# Altium schematic sheet logic.SchDoc
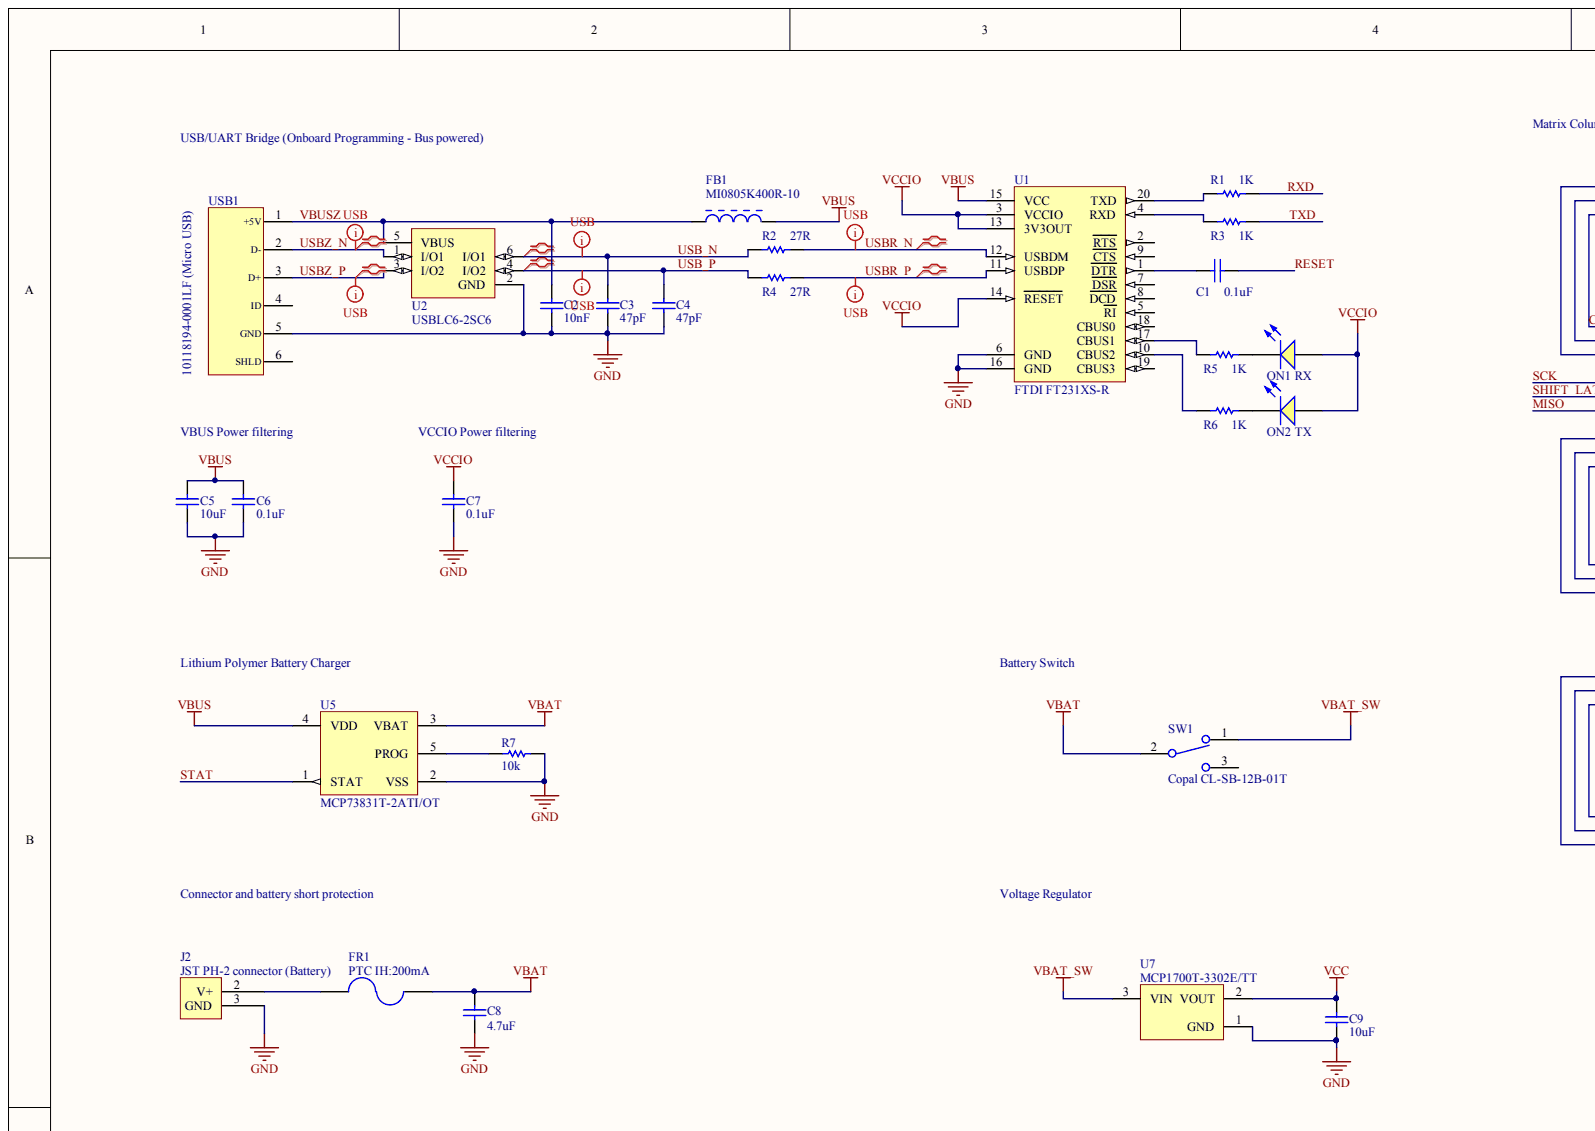
[diagram p0: logic.SchDoc, top left]
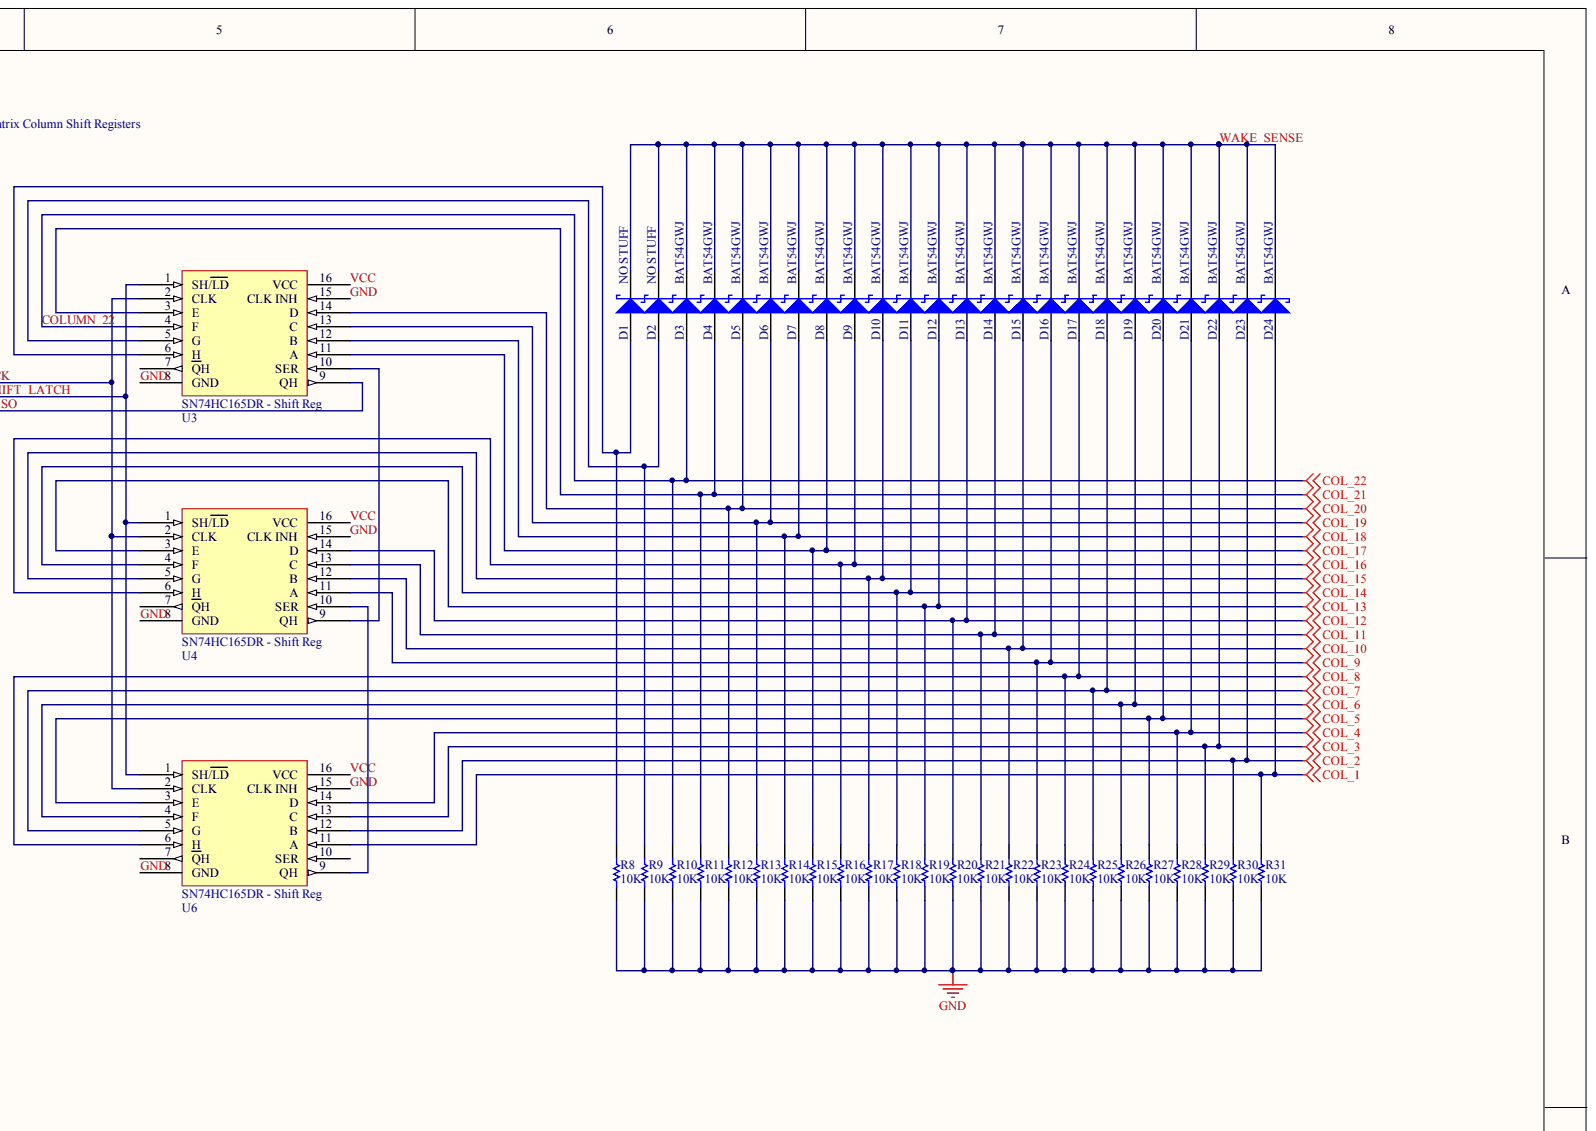
[diagram p1: logic.SchDoc, top right]
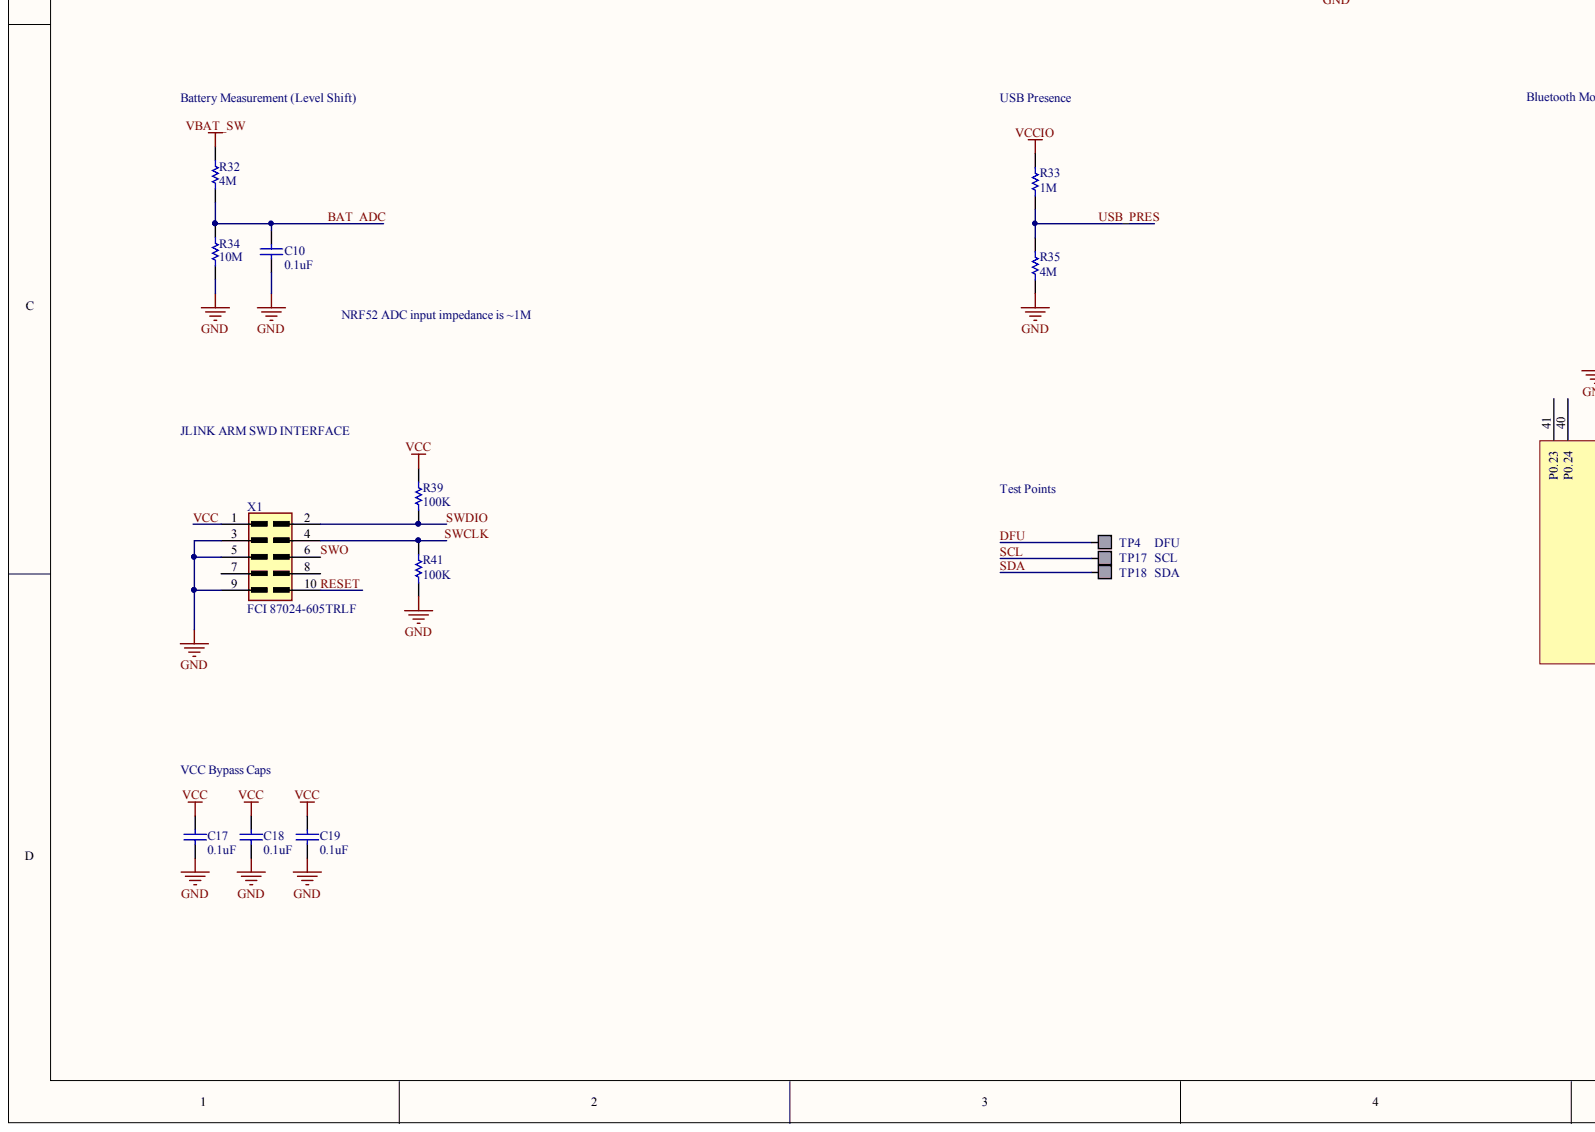
[diagram p2: logic.SchDoc, bottom left]
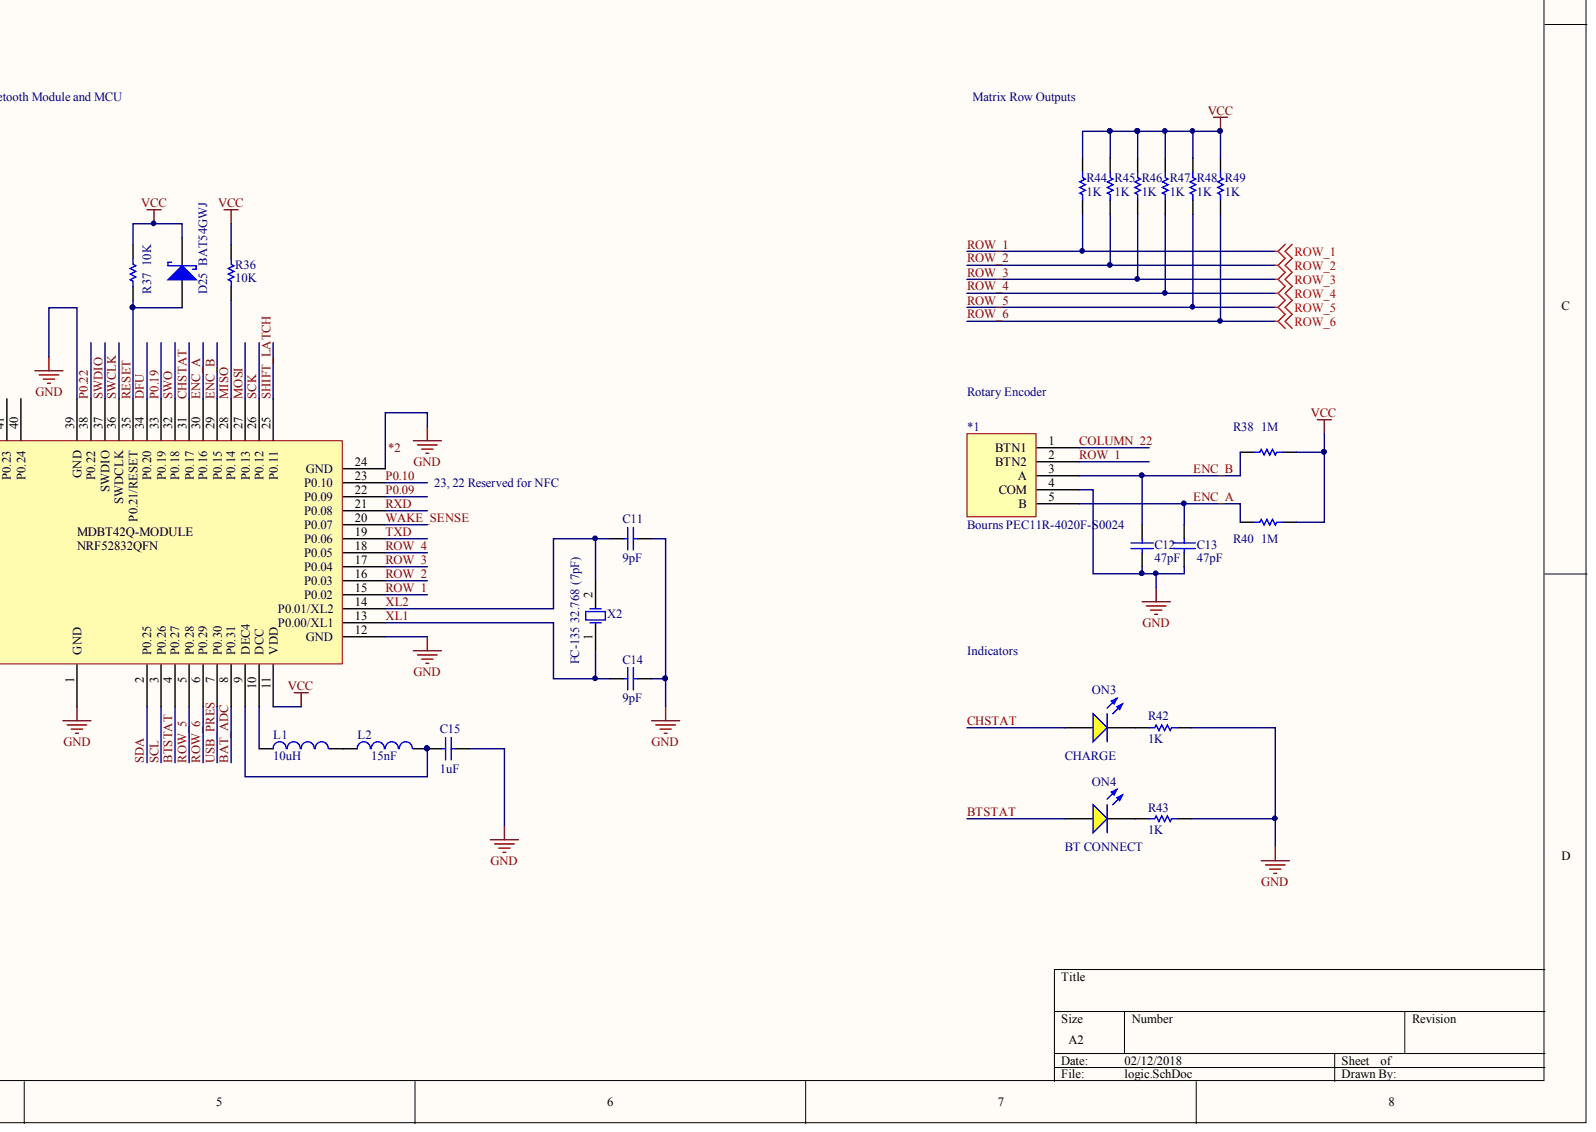
[diagram p3: logic.SchDoc, bottom right]

## Parts
*1  Bourns PEC11R-4020F-S0024  Manufacturer Part Number: PEC11R-4020F-S0024  [PCB-7675167-1]
*2  Raytac MDBT42 BT Module  [PCB-dat7rp2to0iz7tu5ms73-1]  (value not drawn)
C1  0.1uF  [CAPC2013X135X45ML10T25]
C2  10nF  [CAPC2013X135X45ML10T25]
C3  47pF  [CAPC2013X135X45ML10T25]
C4  47pF  [CAPC2013X135X45ML10T25]
C5  10uF  [CAPC2013X135X45ML10T25]
C6  0.1uF  [CAPC2013X135X45ML10T25]
C7  0.1uF  [CAPC2013X135X45ML10T25]
C8  4.7uF  [CAPC2013X135X45ML10T25]
C9  10uF  [CAPC2013X135X45ML10T25]
C10  0.1uF  [CAPC2013X135X45ML10T25]
C11  9pF  [CAPC2013X135X45ML10T25]
C12  47pF  [CAPC2013X135X45ML10T25]
C13  47pF  [CAPC2013X135X45ML10T25]
C14  9pF  [CAPC2013X135X45ML10T25]
C15  1uF  [CAPC2013X135X45ML10T25]
C17  0.1uF  [CAPC2013X135X45ML10T25]
C18  0.1uF  [CAPC2013X135X45ML10T25]
C19  0.1uF  [CAPC2013X135X45ML10T25]
D1  NO STUFF  [PCB-9vocbhu4iqy0s6v5ngz6-1]
D2  NO STUFF  Manufacturer Part Number: BAT54GWJ  [PCB-9vocbhu4iqy0s6v5ngz6-1]
D3  BAT54GWJ  [PCB-9vocbhu4iqy0s6v5ngz6-1]
D4  BAT54GWJ  [PCB-9vocbhu4iqy0s6v5ngz6-1]
D5  BAT54GWJ  [PCB-9vocbhu4iqy0s6v5ngz6-1]
D6  BAT54GWJ  [PCB-9vocbhu4iqy0s6v5ngz6-1]
D7  BAT54GWJ  [PCB-9vocbhu4iqy0s6v5ngz6-1]
D8  BAT54GWJ  [PCB-9vocbhu4iqy0s6v5ngz6-1]
D9  BAT54GWJ  [PCB-9vocbhu4iqy0s6v5ngz6-1]
D10  BAT54GWJ  [PCB-9vocbhu4iqy0s6v5ngz6-1]
D11  BAT54GWJ  [PCB-9vocbhu4iqy0s6v5ngz6-1]
D12  BAT54GWJ  [PCB-9vocbhu4iqy0s6v5ngz6-1]
D13  BAT54GWJ  [PCB-9vocbhu4iqy0s6v5ngz6-1]
D14  BAT54GWJ  [PCB-9vocbhu4iqy0s6v5ngz6-1]
D15  BAT54GWJ  [PCB-9vocbhu4iqy0s6v5ngz6-1]
D16  BAT54GWJ  [PCB-9vocbhu4iqy0s6v5ngz6-1]
D17  BAT54GWJ  [PCB-9vocbhu4iqy0s6v5ngz6-1]
D18  BAT54GWJ  [PCB-9vocbhu4iqy0s6v5ngz6-1]
D19  BAT54GWJ  [PCB-9vocbhu4iqy0s6v5ngz6-1]
D20  BAT54GWJ  [PCB-9vocbhu4iqy0s6v5ngz6-1]
D21  BAT54GWJ  [PCB-9vocbhu4iqy0s6v5ngz6-1]
D22  BAT54GWJ  [PCB-9vocbhu4iqy0s6v5ngz6-1]
D23  BAT54GWJ  [PCB-9vocbhu4iqy0s6v5ngz6-1]
D24  BAT54GWJ  [PCB-9vocbhu4iqy0s6v5ngz6-1]
D25  BAT54GWJ  [PCB-9vocbhu4iqy0s6v5ngz6-1]
FB1  MI0805K400R-10  [MURA-BLM21-H1-CHIP-2_V]
FR1  PTC IH:200mA  [PTC-1206]
J2  JST PH-2 connector (Battery)  [PCB-i8dbac1b5x6rvqm7v5xd-1]
L1  10uH  [PCB-6xavmov8f9jbkmhuz9jz-1]
L2  15nF  [PCB-6xavmov8f9jbkmhuz9jz-1]
ON1  RX  [PCB-mx9kk1rrupqh24oxzoho-1]
ON2  TX  [PCB-mx9kk1rrupqh24oxzoho-1]
ON3  CHARGE  [PCB-mx9kk1rrupqh24oxzoho-1]
ON4  BT CONNECT  [PCB-mx9kk1rrupqh24oxzoho-1]
R1  1K  [RESC2013X60X40ML20T25]
R2  27R  [RESC2013X60X40ML20T25]
R3  1K  [RESC2013X60X40ML20T25]
R4  27R  [RESC2013X60X40ML20T25]
R5  1K  [RESC2013X60X40ML20T25]
R6  1K  [RESC2013X60X40ML20T25]
R7  10k  [RESC2013X60X40ML20T25]
R8  10K  [RESC2013X60X40ML20T25]
R9  10K  [RESC2013X60X40ML20T25]
R10  10K  [RESC2013X60X40ML20T25]
R11  10K  [RESC2013X60X40ML20T25]
R12  10K  [RESC2013X60X40ML20T25]
R13  10K  [RESC2013X60X40ML20T25]
R14  10K  [RESC2013X60X40ML20T25]
R15  10K  [RESC2013X60X40ML20T25]
R16  10K  [RESC2013X60X40ML20T25]
R17  10K  [RESC2013X60X40ML20T25]
R18  10K  [RESC2013X60X40ML20T25]
R19  10K  [RESC2013X60X40ML20T25]
R20  10K  [RESC2013X60X40ML20T25]
R21  10K  [RESC2013X60X40ML20T25]
R22  10K  [RESC2013X60X40ML20T25]
R23  10K  [RESC2013X60X40ML20T25]
R24  10K  [RESC2013X60X40ML20T25]
R25  10K  [RESC2013X60X40ML20T25]
R26  10K  [RESC2013X60X40ML20T25]
R27  10K  [RESC2013X60X40ML20T25]
R28  10K  [RESC2013X60X40ML20T25]
R29  10K  [RESC2013X60X40ML20T25]
R30  10K  [RESC2013X60X40ML20T25]
R31  10K  [RESC2013X60X40ML20T25]
R32  4M  [RESC2013X60X40ML20T25]
R33  1M  [RESC2013X60X40ML20T25]
R34  10M  [RESC2013X60X40ML20T25]
R35  4M  [RESC2013X60X40ML20T25]
R36  10K  [RESC2013X60X40ML20T25]
R37  10K  [RESC2013X60X40ML20T25]
R38  1M  [RESC2013X60X40ML20T25]
R39  100K  [RESC2013X60X40ML20T25]
R40  1M  [RESC2013X60X40ML20T25]
R41  100K  [RESC2013X60X40ML20T25]
R42  1K  [RESC2013X60X40ML20T25]
R43  1K  [RESC2013X60X40ML20T25]
R44  1K  [RESC2013X60X40ML20T25]
R45  1K  [RESC2013X60X40ML20T25]
R46  1K  [RESC2013X60X40ML20T25]
R47  1K  [RESC2013X60X40ML20T25]
R48  1K  [RESC2013X60X40ML20T25]
R49  1K  [RESC2013X60X40ML20T25]
SW1  Copal CL-SB-12B-01T  Manufacturer Part Number: CL-SB-12B-01T  [PCB-9uu377i0ea7oa49ut9v1-1]
TP4  DFU  [PCB-iek5qk9ganu4yn12lo6h-1]  (no BOM)
TP17  SCL  [PCB-iek5qk9ganu4yn12lo6h-1]  (no BOM)
TP18  SDA  [PCB-iek5qk9ganu4yn12lo6h-1]  (no BOM)
U1  FTDI FT231XS-R  Manufacturer Part Number: FT231XS-R  [SSOP20_L]
U2  USBLC6-2SC6  [MF06A_N]
U3  Texas Instruments SN74HC165D  [PCB-aaybsre3rq53r941mfjn-1]  (value not drawn)
U4  Texas Instruments SN74HC165D  [PCB-aaybsre3rq53r941mfjn-1]  (value not drawn)
U5  MCP73831T-2ATI/OT  [SOT-23-OT5_L]
U6  Texas Instruments SN74HC165D  [PCB-aaybsre3rq53r941mfjn-1]  (value not drawn)
U7  MCP1700T-3302E/TT  [SOT-23-TT3_N]
USB1  10118194-0001LF (Micro USB)  [PCB-77ljjqva4nnjq1f4jty9-1]
X1  FCI 87024-605TRLF  [PCB-sv1um6pcy0j9gku5nhxe-1]
X2  FC-135 32.768 (7pF)  [PCB-1zp5wd1v5813cd8flxka-1]

## Nets
1: J2.4
3: J2.1
COL_1: D24.1 R31.2 U6.11(A)
COL_2: D23.1 R30.2 U6.12(B)
COL_3: D22.1 R29.2 U6.13(C)
COL_4: D21.1 R28.2 U6.14(D)
COL_5: D20.1 R27.2 U6.3(E)
COL_6: D19.1 R26.2 U6.4(F)
COL_7: D18.1 R25.2 U6.5(G)
COL_8: D17.1 R24.2 U6.6(H)
COL_9: D16.1 R23.2 U4.11(A)
COL_10: D15.1 R22.2 U4.12(B)
COL_11: D14.1 R21.2 U4.13(C)
COL_12: D13.1 R20.2 U4.14(D)
COL_13: D12.1 R19.2 U4.3(E)
COL_14: D11.1 R18.2 U4.4(F)
COL_15: D10.1 R17.2 U4.5(G)
COL_16: D9.1 R16.2 U4.6(H)
COL_17: D8.1 R15.2 U3.11(A)
COL_18: D7.1 R14.2 U3.12(B)
COL_19: D6.1 R13.2 U3.13(C)
COL_20: D5.1 R12.2 U3.14(D)
COL_21: D4.1 R11.2 U3.3(E)
VBAT: C8.1 FR1.1 SW1.2 U5.3(VBAT)
VBAT_SW: R32.2 SW1.1 U7.3
VBUS: C5.1 C6.1 FB1.2 U1.15(VCC) U5.4(VDD)
VCCIO: C7.1 ON1.2 ON2.2 R33.2 U1.3(VCCIO) U1.13(3V3OUT) U1.14(RESET)
BAT_ADC: *2.8(P0.31) C10.1 R32.1 R34.2
BTSTAT: *2.4(P0.27) ON4.2
CHSTAT: *2.31(P0.17) ON3.2
COLUMN_22: *1.1(BTN1) D3.1 R10.2 U3.4(F)
DFU: *2.34(P0.20) TP4.1
ENC_A: *1.5(B) *2.30(P0.16) C13.2 R40.2
ENC_B: *1.3(A) *2.29(P0.15) C12.2 R38.2
GND: *1.4(COM) *2.1(GND) *2.12(GND) *2.24(GND) *2.39(GND) C2.2 C3.2 C4.2 C5.2 C6.2 C7.2 C8.2 C9.2 C10.2 C11.2 C12.1 C13.1 C14.2 C15.2 C17.2 C18.2 C19.2 J2.3 R7.2 R8.1 R9.1 R10.1 R11.1 R12.1 R13.1 R14.1 R15.1 R16.1 R17.1 R18.1 R19.1 R20.1 R21.1 R22.1 R23.1 R24.1 R25.1 R26.1 R27.1 R28.1 R29.1 R30.1 R31.1 R34.1 R35.1 R41.2 R42.2 R43.2 U1.6(GND) U1.16(GND) U2.2(GND) U3.8(GND) U3.15(CLK_INH) U4.8(GND) U4.15(CLK_INH) U5.2(VSS) U6.8(GND) U6.15(CLK_INH) U7.1 USB1.5(GND) X1.3 X1.5 X1.9
MISO: *2.28(P0.14) R36.1 U3.9(QH)
MOSI: *2.27(P0.13)
P0.09: *2.22(P0.09)
P0.10: *2.23(P0.10)
P0.19: *2.33(P0.19)
P0.22: *2.38(P0.22)
RESET: *2.35(P0.21/RESET) C1.1 D25.1 R37.2 X1.10
ROW_1: *1.2(BTN2) *2.15(P0.02) R44.1
ROW_2: *2.16(P0.03) R45.1
ROW_3: *2.17(P0.04) R46.1
ROW_4: *2.18(P0.05) R47.1
ROW_5: *2.5(P0.28) R48.1
ROW_6: *2.6(P0.29) R49.1
RXD: *2.21(P0.08) R1.1
SCK: *2.26(P0.12) U3.2(CLK) U4.2(CLK) U6.2(CLK)
SCL: *2.3(P0.26) TP17.1
SDA: *2.2(P0.25) TP18.1
SHIFT_LATCH: *2.25(P0.11) U3.1(SH/LD) U4.1(SH/LD) U6.1(SH/LD)
STAT: U5.1(STAT)
SWCLK: *2.36(SWDCLK) R41.1 X1.4
SWDIO: *2.37(SWDIO) R39.2 X1.2
SWO: *2.32(P0.18) X1.6
TXD: *2.19(P0.06) R3.1
USB_N: C3.1 R2.2 U2.6(I/O1)
USB_P: C4.1 R4.2 U2.4(I/O2)
USB_PRES: *2.7(P0.30) R33.1 R35.2
USBR_N: R2.1 U1.12(USBDM)
USBR_P: R4.1 U1.11(USBDP)
USBZ_N: U2.1(I/O1) USB1.2(D-)
USBZ_P: U2.3(I/O2) USB1.3(D+)
VBUSZ: C2.1 FB1.1 U2.5(VBUS) USB1.1(+5V)
VCC: *2.11(VDD) C9.1 C17.1 C18.1 C19.1 D25.2 R36.2 R37.1 R38.1 R39.1 R40.1 R44.2 R45.2 R46.2 R47.2 R48.2 R49.2 U3.16(VCC) U4.16(VCC) U6.16(VCC) U7.2 X1.1
WAKE_SENSE: *2.20(P0.07) D1.2 D2.2 D3.2 D4.2 D5.2 D6.2 D7.2 D8.2 D9.2 D10.2 D11.2 D12.2 D13.2 D14.2 D15.2 D16.2 D17.2 D18.2 D19.2 D20.2 D21.2 D22.2 D23.2 D24.2
XL1: *2.13(P0.00/XL1) C14.1 X2.1
XL2: *2.14(P0.01/XL2) C11.1 X2.2
Net*2_9: *2.9(DEC4) C15.1 L2.2
Net*2_10: *2.10(DCC) L1.1
NetC1_2: C1.2 U1.1(DTR)
NetD1_1: D1.1 R8.2 U3.6(H)
NetD2_1: D2.1 R9.2 U3.5(G)
NetFR1_2: FR1.2 J2.2
NetL1_2: L1.2 L2.1
NetON1_1: ON1.1 R5.1
NetON2_1: ON2.1 R6.1
NetON3_1: ON3.1 R42.1
NetON4_1: ON4.1 R43.1
NetR1_2: R1.2 U1.20(TXD)
NetR3_2: R3.2 U1.4(RXD)
NetR5_2: R5.2 U1.17(CBUS1)
NetR6_2: R6.2 U1.10(CBUS2)
NetR7_1: R7.1 U5.5(PROG)
NetU3_10: U3.10(SER) U4.9(QH)
NetU4_10: U4.10(SER) U6.9(QH)

Not connected: *2 40(P0.24) 41(P0.23); SW1 3; U1 2(RTS) 5(RI) 7(DSR) 8(DCD) 9(CTS) 18(CBUS0) 19(CBUS3); U3 7(QH); U4 7(QH); U6 7(QH) 10(SER); USB1 4(ID) 6(SHLD); X1 7 8

## Library data of the parts
*1 = CMP-47ff8f63fc5f9838-1: Incremental Encoder 24 PPR 2 Bit Quadrature 5VDC at 10 mA IP40 PCB Mount 60RPM; Number of Detents: 0; Supply Voltage (DC): 5.00 V (max); Component Kind: Standard; Library Name: FavoritesLibrary; Mounting Style: PCB; OctopartID: 47ff8f63fc5f9838; Octopart URL: http://octopart.com/pec11r-4020f-s0024-bourns-26648262; Packaging: Bulk; RoHS: Compliant; Size-Height: 13.4 mm; Size-Length: 26.5 mm; Size-Width: 12.5 mm
*2 = CMP-zmvss6yk8n2026t4edoz-3: NRF52832 SoC based Bluetooth Module; Component Kind: Standard; CustomComponentID: zmvss6yk8n2026t4edoz; Library Name: CommunityVault
C1-C15 C17-C19 = CMP-139119-2: Multilayer Ceramic Capacitors MLCC - SMD/SMT 4.7uF 16Volts X6S 10%; Capacitance: 4.7e-06 F; Case/Package (SI): 2012; Lead-Free Status: Lead Free; Voltage Rating (DC): 16.0 V; Capacitance Tolerance: ±10%; Component Kind: Standard; Dielectric Characteristic: X6S; Library Name: CommunityVault; Lifecycle Status: Active; Number of Pins: 2; OctopartID: 2789ef8dfba0f84e; Octopart Url: https://octopart.com/grm21bc81c475ka88l-murata-17758543; Packaging: Cut Tape (CT); REACH SVHC Compliance: No SVHC; RoHS: Compliant; Size-Length: 0.002 m; Size-Thickness: 0.00125 m; Size-Width: 0.00125 m
D1 = CMP-58fd9562de0e873a-1: Diode Schottky 0.2A Automotive 2-Pin SOD-123 T/R; Manufacturer Part Number: BAT54GWJ; Case/Package: SOD-123; Component Kind: Standard; Library Name: FavoritesLibrary; Lifecycle Status: Active; OctopartID: 58fd9562de0e873a; Octopart URL: https://octopart.com/bat54gwj-nxp+semiconductors-76915605
D2-D25 = CMP-58fd9562de0e873a-1: Diode Schottky 0.2A Automotive 2-Pin SOD-123 T/R; Case/Package: SOD-123; Component Kind: Standard; Library Name: FavoritesLibrary; Lifecycle Status: Active; OctopartID: 58fd9562de0e873a; Octopart URL: https://octopart.com/bat54gwj-nxp+semiconductors-76915605
  D3-D25: Manufacturer Part Number: BAT54GWJ
FB1 = CMP-19299605-4: Chip Ferrite Bead for General Signal lines, 600 Ohm, 600 mA, -55 to 125 degC, 2 x 1.25 x 1.05 mm SMD, Tape and Reel; Case/Package (SI): 2012; Conductor Material: Copper; Current Rating: 0.2 A; Frequency: 100000000.0 Hz; Lead-Free Status: Lead Free; Number of Circuits: 1; Resistance: 600.0 Ω; Shielding: No; Temperature Coefficient: 10.0 ppm/°C; Case/Package: 0805; Component Kind: Standard; Library Name: CommunityVault; Mounting Style: Surface Mount; Number of Pins: 2; OctopartID: 6f63a66983c1b0a4; Octopart Url: https://octopart.com/blm21ag601sn1d-murata-51652; REACH SVHC Compliance: No SVHC; RoHS: Compliant; Size-Height: 0.00085 m; Size-Length: 0.002 m; Size-Width: 0.00125 m
FR1 = CMP-162adf289c6dc9ed-1: PTC Resettable Fuse 0.2A(hold) 0.42A(trip) 24VDC 100A 0.6W 0.1s 0.65Ohm SMD Solder Pad 1206 T/R; Manufacturer Part Number: 1206L020YR; Case/Package (SI): 3216; Current Rating: 200 mA; Operating Voltage: 24.0 V; Case/Package: 1206; Component Kind: Standard; Library Name: CommunityVault; Mounting Style: Surface Mount; Octopart URL: http://octopart.com/1206l020yr-littelfuse-569121; REACH SVHC Compliance: No SVHC; Size-Height: 1.00 mm; Size-Length: 3.25 mm; Size-Width: 1.65 mm; Voltage Rating (DC): 24.0 V
J2 = CMP-eb8b212aa438344c-2: Lamp; 2.5 V; 0.2 A; 0.200 MSCP; 500 hr; 0.23 in. (Max.); 0.625 in. (Max.); C-2R; Component Kind: Standard; Library Name: CommunityVault; Octopart URL: http://octopart.com/1769-adafruit+industries-61565653; RoHS: Non-Compliant
L1 L2 = CMP-8d95449a41975252-2: Ind General Purpose Chip Shielded Multi-Layer 2.2uH 10% 10MHz 45Q-Factor Ferrite 30mA 0805 Paper T/R; Manufacturer Part Number: LQM21NN2R2K10D; Case/Package (SI): 2012; Inductance: 2.2e-06 H; Lead-Free Status: Lead Free; Self Resonant Frequency: 71000000.0 Hz; Shielding: Yes; Case/Package: 0805, 2012; Component Kind: Standard; Library Name: CommunityVault; Lifecycle Status: Active; Mounting Style: Surface Mount; OctopartID: 8d95449a41975252; Octopart URL: http://octopart.com/lqm21nn2r2k10d-murata-387428; Packaging: Reel; REACH SVHC Compliance: No SVHC; RoHS: Compliant; Size-Height: 0.00085 m; Size-Length: 0.002 m; Size-Width: 0.00125 m
ON1-ON4 = CMP-6caaa5a82dcdb36d-1: Red 2 x 1.25 x 0.8 mm 130° Water Clear 54 mcd 2 V Surface Mount LED; Manufacturer Part Number: LTST-C171KRKT; Case/Package (SI): 2012; Forward Voltage: 2.00 V; Lead-Free Status: Lead Free; Lens Type: Non-Diffused; Luminous Intensity: 54.0 mcd; Wavelength: 639 nm; Case/Package: 0805; Color: Red; Component Kind: Standard; Library Name: FavoritesLibrary; Mounting Style: Surface Mount, Vertical; OctopartID: 6caaa5a82dcdb36d; Octopart URL: http://octopart.com/ltst-c171krkt-lite-on-549889; Packaging: Cut Tape (CT), Tape & Reel (TR); RoHS: Compliant; Size-Height: 800 µm; Size-Length: 2.00 mm; Size-Width: 1.25 mm; Viewing Angle: 130 °
R1-R49 = CMP-2531519-2: RESISTOR, 0805, 15K8, 0.1%, 0.125W; Resistance: 15800.0 Ω; Voltage Rating (DC): 100.0 V; Component Kind: Standard; Library Name: CommunityVault; Lifecycle Status: Not Listed by Manufacturer; Mounting Style: Surface Mount; Number of Pins: 2; OctopartID: 66fcad787e28b93b; Octopart Url: https://octopart.com/era6aeb1582v-panasonic-42876338; Packaging: Tape & Reel (TR); Power Rating: 0.125 W; REACH SVHC Compliance: No SVHC; Resistance Tolerance: ±0.1%; RoHS: Compliant
SW1 = CMP-eb522328bab63495-5: Switch Slide N.O./N.C. SPDT Top Slide 0.2A 12VDC 10000Cycles Gull Wing SMD T/R; Component Kind: Standard; Library Name: CommunityVault; OctopartID: eb522328bab63495; Octopart URL: http://octopart.com/cl-sb-12b-01t-copal-24147074
TP4 TP17 TP18 = CMP-da21135b2be305e6-8: Raychem; SMT Circuit probe pad, 0805, 0R0 RCT; Library Name: CommunityVault
U1 = CMP-1315745-1.A.4: USB Full Speed to Full Handshake UART with USB Charger Detection; Component Kind: Standard; Library Name: CommunityVault; Mounting Style: Surface Mount; OctopartID: 526a9a30d6cf8dad; Octopart Url: http://octopart.com/ft231xs-r-ftdi-22061507; Packaging: Tape; RoHS: Compliant; Seller Landing  Url: https://octopart-clicks.com/click/track?country=US&ak=8157f166&sig=0ac6205&sid=459&ppid=22061507&vpid=46595747&ct=offers
U2 = CMP-756ebe39126caaa9-7: USBLC6 Series 2 Line 6 V Uni / Bi-Directional ESD Protection - SOT-23-6; Component Kind: Standard; Library Name: CommunityVault
U3 U4 U6 = CMP-0c54c5598ae3d393-7: Logic IC - Shift register Texas Instruments SN74HC165DR Shift register Differential SOIC 16 N; Component Kind: Standard; Library Name: CommunityVault
U5 = CMP-961386-5: Miniature Single-Cell, Fully Integrated Li-Ion, Li-Polymer Charge Management Controller, 4.2V, 5-Pin SOT-23, Industrial Temperature, Tape and Reel; Lead-Free Status: Lead Free; Case/Package: SOT-23; Component Kind: Standard; Library Name: CommunityVault; Lifecycle Status: Active; Mounting Style: Surface Mount; Number of Pins: 5; OctopartID: 8910401cdf0a56d7; Octopart Url: https://octopart.com/mcp73831t-2ati%2Fot-microchip-422780; REACH SVHC Compliance: No SVHC; RoHS: Compliant
U7 = CMP-1493168-3: Low Quiescent Current LDO, 3-Pin SOT-23, Extended Temperature, Tape and Reel; Dropout Voltage: 0.178 V; Lead-Free Status: Lead Free; Number of Outputs: 1; Output Capacitor Type: Ceramic; Quiescent Current: 1.6e-06 A; Case/Package: SOT-23; Component Kind: Standard; Library Name: CommunityVault; Lifecycle Status: Active; Mounting Style: Surface Mount; Number of Pins: 3; OctopartID: fa09330bf3d125ce; Octopart Url: https://octopart.com/mcp1700t-3302e%2Ftt-microchip-535742; REACH SVHC Compliance: No SVHC; RoHS: Compliant
USB1 = CMP-86f04ebedf8a5937-36: Conn Micro USB Type B RCP 5 POS 0.65mm Solder RA SMD 5 Terminal 1 Port T/R; Component Kind: Standard; Library Name: CommunityVault; OctopartID: 86f04ebedf8a5937; Octopart URL: https://octopart.com/10118194-0001lf-fci-21265436; Packaging: Cut Tape (CT)
X1 = CMP-7911b4617bd7a6d6-1: Conn Unshrouded Header HDR 10 POS 1.27mm Solder ST SMD T/R; Manufacturer Part Number: 20021121-00010C4LF; Gender: Male; Number of Contacts: 10; Component Kind: Standard; Contact Material: Copper Alloy; Contact Plating: Gold; Library Name: CommunityVault; Mounting Style: Surface Mount, Vertical; OctopartID: 7911b4617bd7a6d6; Packaging: Reel; REACH SVHC Compliance: No SVHC
X2 = CMP-533e9910b4df8cf9-1: Crystal 0.032768MHz ±20ppm (Tol) 7pF FUND 70000Ohm 2-Pin SMD T/R; Manufacturer Part Number: FC-135 32.7680KA-AG0; Component Kind: Standard; Library Name: CommunityVault; OctopartID: 533e9910b4df8cf9; Octopart URL: http://octopart.com/fc-135+32.7680ka-ag0-epson-52740743; Packaging: Reel; RoHS: Compliant
